# Altium schematic sheet audioAmp.SchDoc
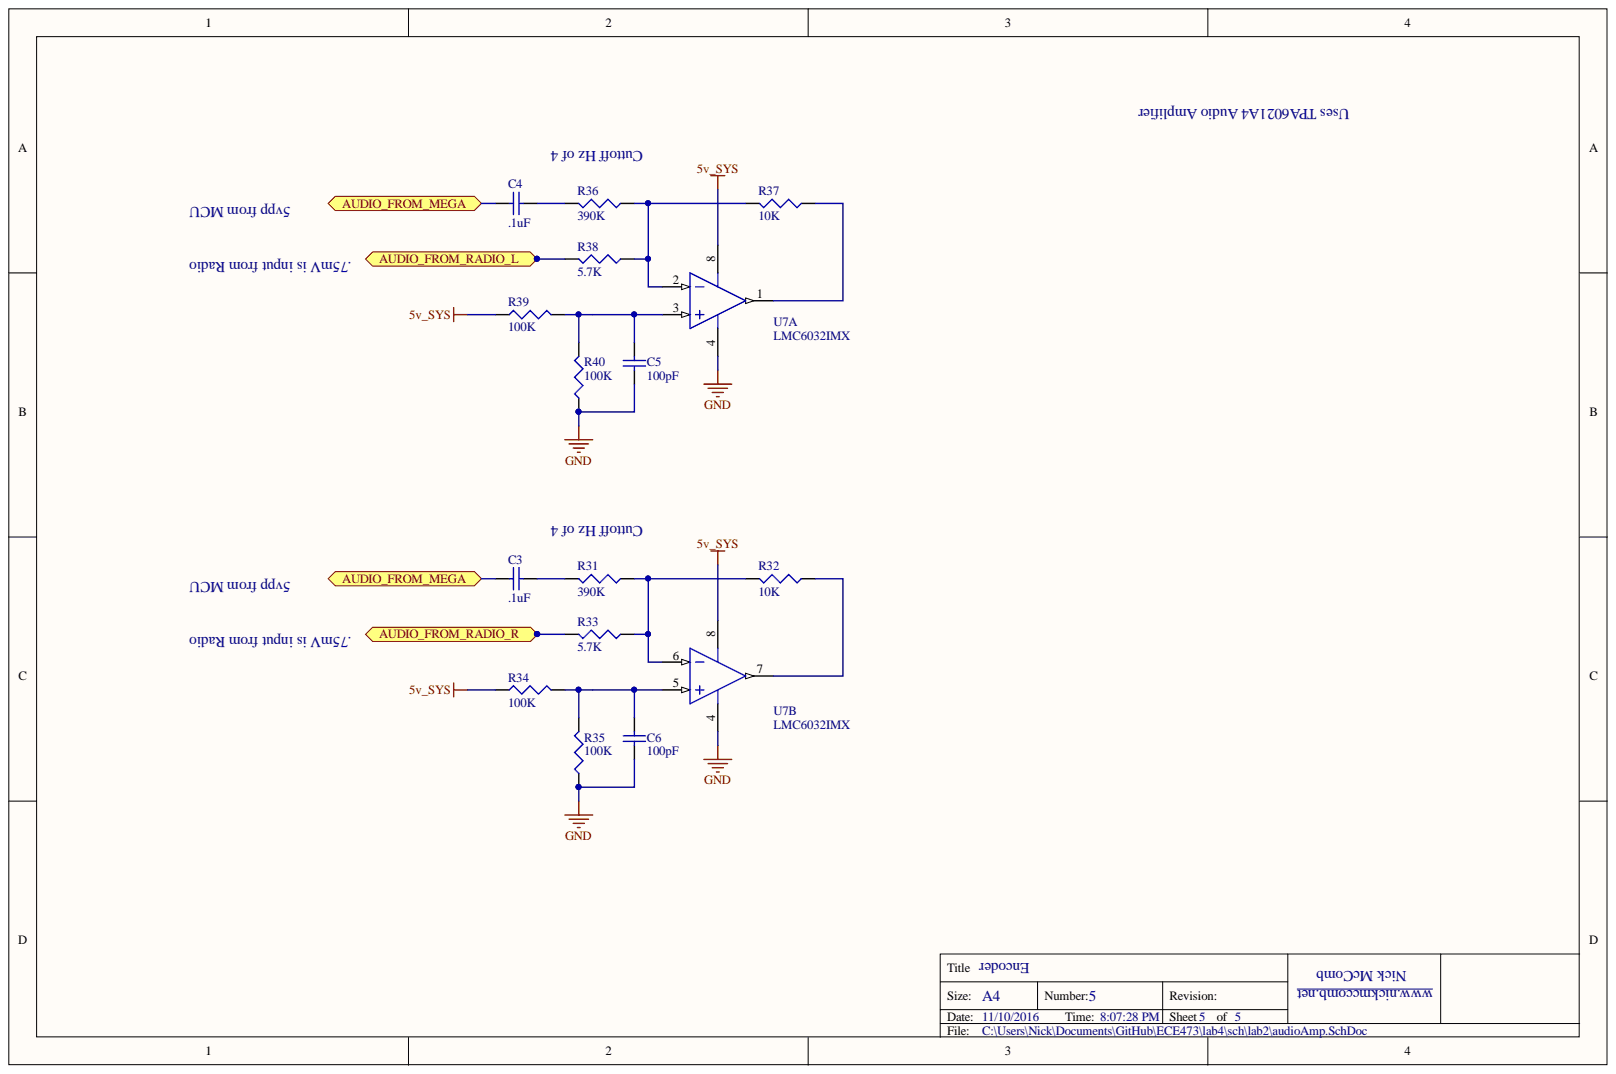
[diagram p0: audioAmp.SchDoc]

## Parts
C3  value .1uF  [C0805]  (hidden comment: 0805 style, by default)
C4  value .1uF  [C0805]  (hidden comment: 0805 style, by default)
C5  value 100pF  [C0805]  (hidden comment: 0805 style, by default)
C6  value 100pF  [C0805]  (hidden comment: 0805 style, by default)
R31  390K  [J1-0603]
R32  10K  [J1-0603]
R33  5.7K  [J1-0603]
R34  100K  [J1-0603]
R35  100K  [J1-0603]
R36  390K  [J1-0603]
R37  10K  [J1-0603]
R38  5.7K  [J1-0603]
R39  100K  [J1-0603]
R40  100K  [J1-0603]
U7  LMC6032IMX  [D0008A_M]  (parts A,B)

## Ports
AUDIO_FROM_MEGA, AUDIO_FROM_RADIO_L, AUDIO_FROM_RADIO_R

## Nets
5V_SYS: R34.1 R39.1 U7.8
GND: C5.1 C6.1 R35.1 R40.1 U7.4
AUDIO_FROM_MEGA: C3.1 C4.1 ; port AUDIO_FROM_MEGA
AUDIO_FROM_RADIO_L: R38.2 ; port AUDIO_FROM_RADIO_L
AUDIO_FROM_RADIO_R: R33.2 ; port AUDIO_FROM_RADIO_R
NetC3_2: C3.2 R31.2
NetC4_2: C4.2 R36.2
NetC5_2: C5.2 R39.2 R40.2 U7.3
NetC6_2: C6.2 R34.2 R35.2 U7.5
NetR31_1: R31.1 R32.2 R33.1 U7.6
NetR32_1: R32.1 U7.7
NetR36_1: R36.1 R37.2 R38.1 U7.2
NetR37_1: R37.1 U7.1

## Library data of the parts
C3-C6 = Cap: Capacitor; Component Kind: Standard; LatestRevisionDate: 17-Jul-2002; LatestRevisionNote: Re-released for DXP Platform.; Library Name: lab2.SchLib; PackageDescription: Radial Cap, Thru-Hole; 2 Leads; 0.3 in Pin Spacing; PackageReference: RAD-0.3; Published: 8-Jun-2000; Signal Integrity: Cap; Simulation: CAP; Publisher: Altium Limited
R31-R40 = Res3: Resistor; Component Kind: Standard; LatestRevisionDate: 29-May-2009; LatestRevisionNote: IPC-7351 Footprint Added.; Library Name: lab2.SchLib; PackageDescription: Chip Resistor; PackageReference: J1-0603; Published: 8-Jun-2000; Publisher: Altium Limited
  R31 R36: Value: 390K
  R32 R37: Value: 10K
  R33 R38: Value: 5.7K
  R34 R35 R39 R40: Value: 100K
U7 = CMP-0055-00692-3: CMOS Dual Operational Amplifier, 8-pin Narrow SOIC; Manufacturer: Texas Instruments; CompType: Amplifiers; PackageTopMarking: NSZXTT*LMC60*32IM; PowerWise: N; Code_IPC: SOIC127P600-8; Code_JEDEC: MS-012-AA; Component Kind: Standard; ComponentLink1Description: Datasheet URL; ComponentLink1URL: http://www.ti.com/general/docs/lit/getliterature.tsp?genericPartNumber=LMC6032&fileType=pdf; Library Name: Altium Content Vault; PackageDescription: SOIC Narrow, 4.9 x 3.9 x 1.45mm, 8 Lead, 1.27mm Pitch; Package Reference: D0008A; PartId: 1; PinCount: 8; RoHS: N
